# Altium schematic sheet 20-002314-00 Pg3 TP1.SchDoc
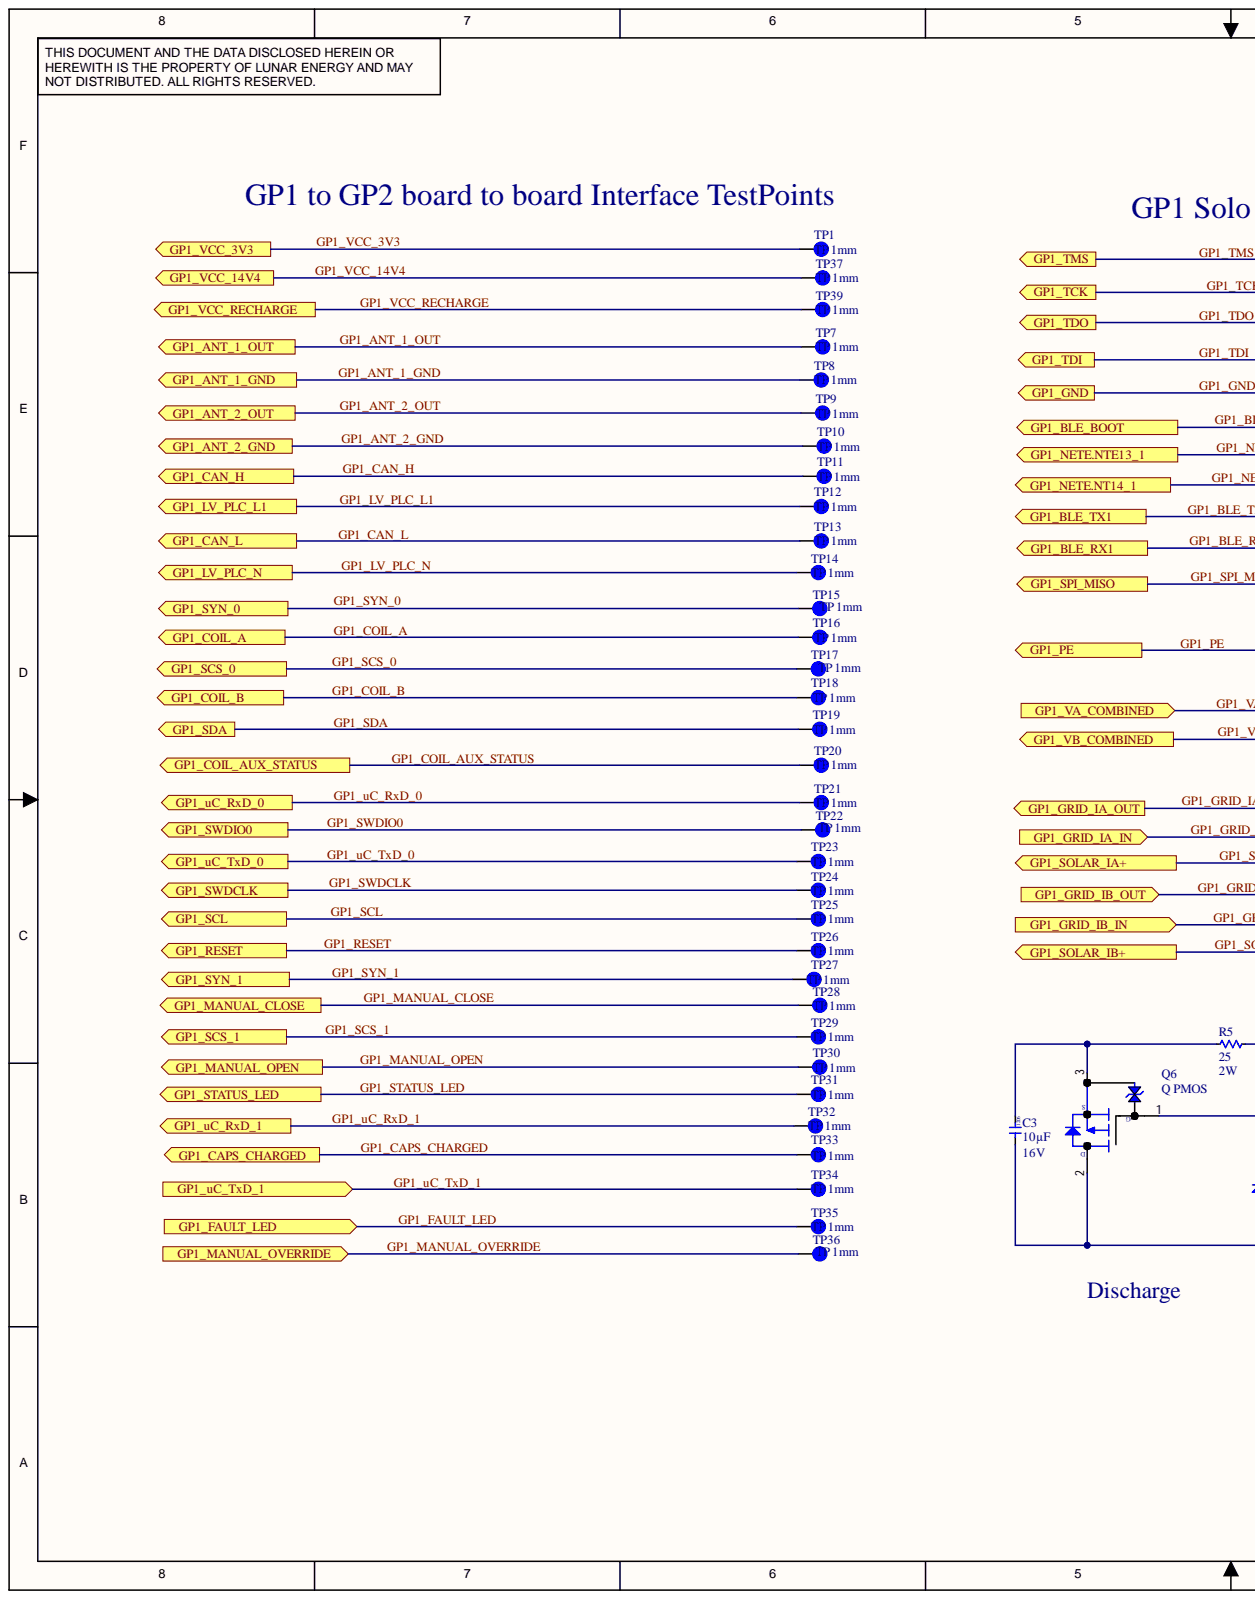
[diagram p0: 20-002314-00 Pg3 TP1.SchDoc, left]
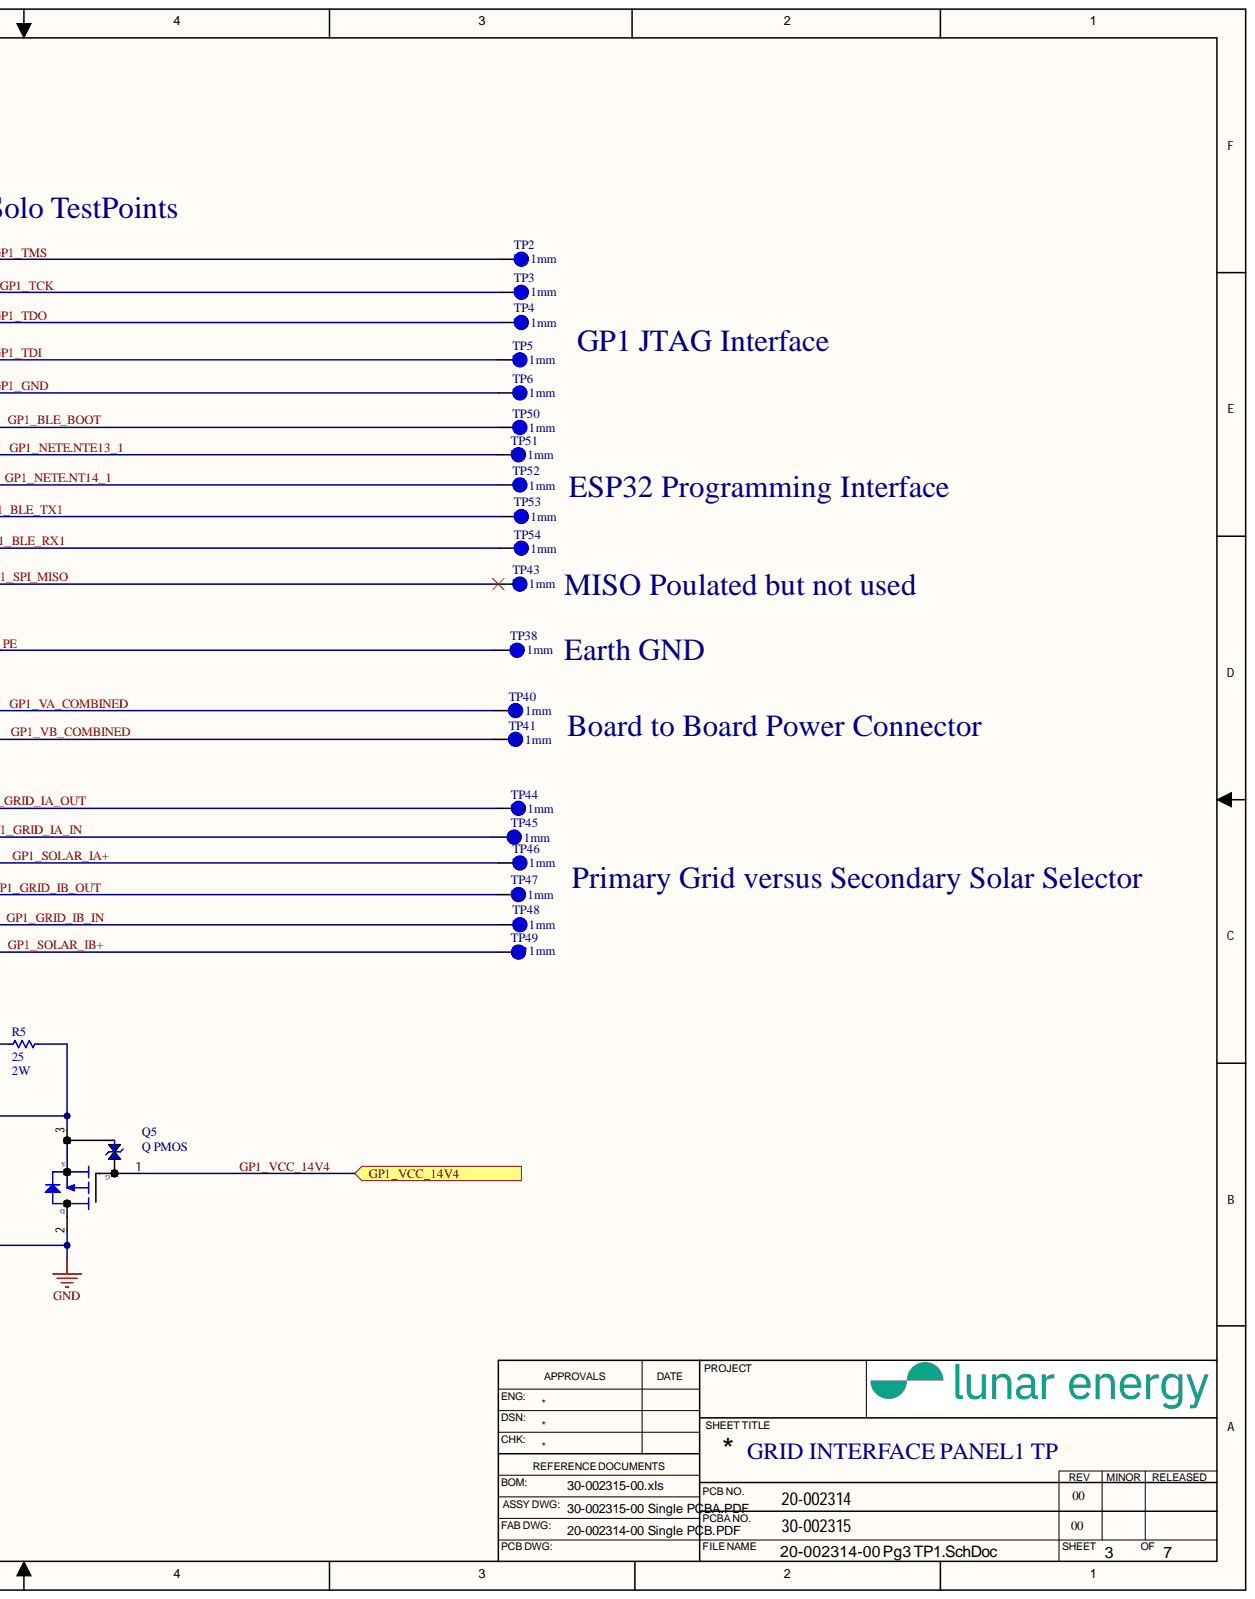
[diagram p1: 20-002314-00 Pg3 TP1.SchDoc, right]

## Parts
C3  value 10µF  DC Voltage Rating: 16V  Size Code: 1206  [CAPC1206 - Lunar]  (hidden comment: CNA5L1X7R1C106K160AE)
Q5  Lunar Sym Name: Q PMOS  [Q PMOS 30V 6A 42mOHM-Footprint-1]  (hidden comment: Q PMOS 30V 6A 42mOHM)
Q6  Lunar Sym Name: Q PMOS  [Q PMOS 30V 6A 42mOHM-Footprint-1]  (hidden comment: Q PMOS 30V 6A 42mOHM)
R5  value 25  Power: 2W  [RESC6435X06N]  (hidden comment: RCP2512W25R0GEB)
TP1  Lunar Sym Name: TP 1mm  [Test Point 1MM Round-Footprint-1]  (hidden comment: Test Point 1mm Round)  (no BOM)
TP2  Lunar Sym Name: TP 1mm  [Test Point 1MM Round-Footprint-1]  (hidden comment: Test Point 1mm Round)  (no BOM)
TP3  Lunar Sym Name: TP 1mm  [Test Point 1MM Round-Footprint-1]  (hidden comment: Test Point 1mm Round)  (no BOM)
TP4  Lunar Sym Name: TP 1mm  [Test Point 1MM Round-Footprint-1]  (hidden comment: Test Point 1mm Round)  (no BOM)
TP5  Lunar Sym Name: TP 1mm  [Test Point 1MM Round-Footprint-1]  (hidden comment: Test Point 1mm Round)  (no BOM)
TP6  Lunar Sym Name: TP 1mm  [Test Point 1MM Round-Footprint-1]  (hidden comment: Test Point 1mm Round)  (no BOM)
TP7  Lunar Sym Name: TP 1mm  [Test Point 1MM Round-Footprint-1]  (hidden comment: Test Point 1mm Round)  (no BOM)
TP8  Lunar Sym Name: TP 1mm  [Test Point 1MM Round-Footprint-1]  (hidden comment: Test Point 1mm Round)  (no BOM)
TP9  Lunar Sym Name: TP 1mm  [Test Point 1MM Round-Footprint-1]  (hidden comment: Test Point 1mm Round)  (no BOM)
TP10  Lunar Sym Name: TP 1mm  [Test Point 1MM Round-Footprint-1]  (hidden comment: Test Point 1mm Round)  (no BOM)
TP11  Lunar Sym Name: TP 1mm  [Test Point 1MM Round-Footprint-1]  (hidden comment: Test Point 1mm Round)  (no BOM)
TP12  Lunar Sym Name: TP 1mm  [Test Point 1MM Round-Footprint-1]  (hidden comment: Test Point 1mm Round)  (no BOM)
TP13  Lunar Sym Name: TP 1mm  [Test Point 1MM Round-Footprint-1]  (hidden comment: Test Point 1mm Round)  (no BOM)
TP14  Lunar Sym Name: TP 1mm  [Test Point 1MM Round-Footprint-1]  (hidden comment: Test Point 1mm Round)  (no BOM)
TP15  Lunar Sym Name: TP 1mm  [Test Point 1MM Round-Footprint-1]  (hidden comment: Test Point 1mm Round)  (no BOM)
TP16  Lunar Sym Name: TP 1mm  [Test Point 1MM Round-Footprint-1]  (hidden comment: Test Point 1mm Round)  (no BOM)
TP17  Lunar Sym Name: TP 1mm  [Test Point 1MM Round-Footprint-1]  (hidden comment: Test Point 1mm Round)  (no BOM)
TP18  Lunar Sym Name: TP 1mm  [Test Point 1MM Round-Footprint-1]  (hidden comment: Test Point 1mm Round)  (no BOM)
TP19  Lunar Sym Name: TP 1mm  [Test Point 1MM Round-Footprint-1]  (hidden comment: Test Point 1mm Round)  (no BOM)
TP20  Lunar Sym Name: TP 1mm  [Test Point 1MM Round-Footprint-1]  (hidden comment: Test Point 1mm Round)  (no BOM)
TP21  Lunar Sym Name: TP 1mm  [Test Point 1MM Round-Footprint-1]  (hidden comment: Test Point 1mm Round)  (no BOM)
TP22  Lunar Sym Name: TP 1mm  [Test Point 1MM Round-Footprint-1]  (hidden comment: Test Point 1mm Round)  (no BOM)
TP23  Lunar Sym Name: TP 1mm  [Test Point 1MM Round-Footprint-1]  (hidden comment: Test Point 1mm Round)  (no BOM)
TP24  Lunar Sym Name: TP 1mm  [Test Point 1MM Round-Footprint-1]  (hidden comment: Test Point 1mm Round)  (no BOM)
TP25  Lunar Sym Name: TP 1mm  [Test Point 1MM Round-Footprint-1]  (hidden comment: Test Point 1mm Round)  (no BOM)
TP26  Lunar Sym Name: TP 1mm  [Test Point 1MM Round-Footprint-1]  (hidden comment: Test Point 1mm Round)  (no BOM)
TP27  Lunar Sym Name: TP 1mm  [Test Point 1MM Round-Footprint-1]  (hidden comment: Test Point 1mm Round)  (no BOM)
TP28  Lunar Sym Name: TP 1mm  [Test Point 1MM Round-Footprint-1]  (hidden comment: Test Point 1mm Round)  (no BOM)
TP29  Lunar Sym Name: TP 1mm  [Test Point 1MM Round-Footprint-1]  (hidden comment: Test Point 1mm Round)  (no BOM)
TP30  Lunar Sym Name: TP 1mm  [Test Point 1MM Round-Footprint-1]  (hidden comment: Test Point 1mm Round)  (no BOM)
TP31  Lunar Sym Name: TP 1mm  [Test Point 1MM Round-Footprint-1]  (hidden comment: Test Point 1mm Round)  (no BOM)
TP32  Lunar Sym Name: TP 1mm  [Test Point 1MM Round-Footprint-1]  (hidden comment: Test Point 1mm Round)  (no BOM)
TP33  Lunar Sym Name: TP 1mm  [Test Point 1MM Round-Footprint-1]  (hidden comment: Test Point 1mm Round)  (no BOM)
TP34  Lunar Sym Name: TP 1mm  [Test Point 1MM Round-Footprint-1]  (hidden comment: Test Point 1mm Round)  (no BOM)
TP35  Lunar Sym Name: TP 1mm  [Test Point 1MM Round-Footprint-1]  (hidden comment: Test Point 1mm Round)  (no BOM)
TP36  Lunar Sym Name: TP 1mm  [Test Point 1MM Round-Footprint-1]  (hidden comment: Test Point 1mm Round)  (no BOM)
TP37  Lunar Sym Name: TP 1mm  [Test Point 1MM Round-Footprint-1]  (hidden comment: Test Point 1mm Round)  (no BOM)
TP38  Lunar Sym Name: TP 1mm  [Test Point 1MM Round-Footprint-1]  (hidden comment: Test Point 1mm Round)  (no BOM)
TP39  Lunar Sym Name: TP 1mm  [Test Point 1MM Round-Footprint-1]  (hidden comment: Test Point 1mm Round)  (no BOM)
TP40  Lunar Sym Name: TP 1mm  [Test Point 1MM Round-Footprint-1]  (hidden comment: Test Point 1mm Round)  (no BOM)
TP41  Lunar Sym Name: TP 1mm  [Test Point 1MM Round-Footprint-1]  (hidden comment: Test Point 1mm Round)  (no BOM)
TP43  Lunar Sym Name: TP 1mm  [Test Point 1MM Round-Footprint-1]  (hidden comment: Test Point 1mm Round)  (no BOM)
TP44  Lunar Sym Name: TP 1mm  [Test Point 1MM Round-Footprint-1]  (hidden comment: Test Point 1mm Round)  (no BOM)
TP45  Lunar Sym Name: TP 1mm  [Test Point 1MM Round-Footprint-1]  (hidden comment: Test Point 1mm Round)  (no BOM)
TP46  Lunar Sym Name: TP 1mm  [Test Point 1MM Round-Footprint-1]  (hidden comment: Test Point 1mm Round)  (no BOM)
TP47  Lunar Sym Name: TP 1mm  [Test Point 1MM Round-Footprint-1]  (hidden comment: Test Point 1mm Round)  (no BOM)
TP48  Lunar Sym Name: TP 1mm  [Test Point 1MM Round-Footprint-1]  (hidden comment: Test Point 1mm Round)  (no BOM)
TP49  Lunar Sym Name: TP 1mm  [Test Point 1MM Round-Footprint-1]  (hidden comment: Test Point 1mm Round)  (no BOM)
TP50  Lunar Sym Name: TP 1mm  [Test Point 1MM Round-Footprint-1]  (hidden comment: Test Point 1mm Round)  (no BOM)
TP51  Lunar Sym Name: TP 1mm  [Test Point 1MM Round-Footprint-1]  (hidden comment: Test Point 1mm Round)  (no BOM)
TP52  Lunar Sym Name: TP 1mm  [Test Point 1MM Round-Footprint-1]  (hidden comment: Test Point 1mm Round)  (no BOM)
TP53  Lunar Sym Name: TP 1mm  [Test Point 1MM Round-Footprint-1]  (hidden comment: Test Point 1mm Round)  (no BOM)
TP54  Lunar Sym Name: TP 1mm  [Test Point 1MM Round-Footprint-1]  (hidden comment: Test Point 1mm Round)  (no BOM)

## Ports
GP1_ANT_1_GND, GP1_ANT_1_OUT, GP1_ANT_2_GND, GP1_ANT_2_OUT, GP1_BLE_BOOT, GP1_BLE_RX1, GP1_BLE_TX1, GP1_CAN_H, GP1_CAN_L, GP1_CAPS_CHARGED, GP1_COIL_A, GP1_COIL_AUX_STATUS, GP1_COIL_B, GP1_FAULT_LED, GP1_GND, GP1_GRID_IA_IN, GP1_GRID_IA_OUT, GP1_GRID_IB_IN, GP1_GRID_IB_OUT, GP1_LV_PLC_L1, GP1_LV_PLC_N, GP1_MANUAL_CLOSE, GP1_MANUAL_OPEN, GP1_MANUAL_OVERRIDE, GP1_NETE.NT14_1, GP1_NETE.NTE13_1, GP1_PE, GP1_RESET, GP1_SCL, GP1_SCS_0, GP1_SCS_1, GP1_SDA, GP1_SOLAR_IA+, GP1_SOLAR_IB+, GP1_SPI_MISO, GP1_STATUS_LED, GP1_SWDCLK, GP1_SWDIO0, GP1_SYN_0, GP1_SYN_1, GP1_TCK, GP1_TDI, GP1_TDO, GP1_TMS, GP1_uC_RxD_0, GP1_uC_RxD_1, GP1_uC_TxD_0, GP1_uC_TxD_1, GP1_VA_COMBINED, GP1_VB_COMBINED, GP1_VCC_3V3, GP1_VCC_14V4, GP1_VCC_RECHARGE

## Nets
GND: C3.2 Q5.2 Q6.2
GP1_ANT_1_GND: TP8.1 ; port GP1_ANT_1_GND
GP1_ANT_1_OUT: TP7.1 ; port GP1_ANT_1_OUT
GP1_ANT_2_GND: TP10.1 ; port GP1_ANT_2_GND
GP1_ANT_2_OUT: TP9.1 ; port GP1_ANT_2_OUT
GP1_BLE_BOOT: TP50.1 ; port GP1_BLE_BOOT
GP1_BLE_RX1: TP54.1 ; port GP1_BLE_RX1
GP1_BLE_TX1: TP53.1 ; port GP1_BLE_TX1
GP1_CAN_H: TP11.1 ; port GP1_CAN_H
GP1_CAN_L: TP13.1 ; port GP1_CAN_L
GP1_CAPS_CHARGED: TP33.1 ; port GP1_CAPS_CHARGED
GP1_COIL_A: TP16.1 ; port GP1_COIL_A
GP1_COIL_AUX_STATUS: TP20.1 ; port GP1_COIL_AUX_STATUS
GP1_COIL_B: TP18.1 ; port GP1_COIL_B
GP1_FAULT_LED: TP35.1 ; port GP1_FAULT_LED
GP1_GND: TP6.1 ; port GP1_GND
GP1_GRID_IA_IN: TP45.1 ; port GP1_GRID_IA_IN
GP1_GRID_IA_OUT: TP44.1 ; port GP1_GRID_IA_OUT
GP1_GRID_IB_IN: TP48.1 ; port GP1_GRID_IB_IN
GP1_GRID_IB_OUT: TP47.1 ; port GP1_GRID_IB_OUT
GP1_LV_PLC_L1: TP12.1 ; port GP1_LV_PLC_L1
GP1_LV_PLC_N: TP14.1 ; port GP1_LV_PLC_N
GP1_MANUAL_CLOSE: TP28.1 ; port GP1_MANUAL_CLOSE
GP1_MANUAL_OPEN: TP30.1 ; port GP1_MANUAL_OPEN
GP1_MANUAL_OVERRIDE: TP36.1 ; port GP1_MANUAL_OVERRIDE
GP1_NETE.NT14_1: TP52.1 ; port GP1_NETE.NT14_1
GP1_NETE.NTE13_1: TP51.1 ; port GP1_NETE.NTE13_1
GP1_PE: TP38.1 ; port GP1_PE
GP1_RESET: TP26.1 ; port GP1_RESET
GP1_SCL: TP25.1 ; port GP1_SCL
GP1_SCS_0: TP17.1 ; port GP1_SCS_0
GP1_SCS_1: TP29.1 ; port GP1_SCS_1
GP1_SDA: TP19.1 ; port GP1_SDA
GP1_SOLAR_IA+: TP46.1 ; port GP1_SOLAR_IA+
GP1_SOLAR_IB+: TP49.1 ; port GP1_SOLAR_IB+
GP1_SPI_MISO: TP43.1 ; port GP1_SPI_MISO
GP1_STATUS_LED: TP31.1 ; port GP1_STATUS_LED
GP1_SWDCLK: TP24.1 ; port GP1_SWDCLK
GP1_SWDIO0: TP22.1 ; port GP1_SWDIO0
GP1_SYN_0: TP15.1 ; port GP1_SYN_0
GP1_SYN_1: TP27.1 ; port GP1_SYN_1
GP1_TCK: TP3.1 ; port GP1_TCK
GP1_TDI: TP5.1 ; port GP1_TDI
GP1_TDO: TP4.1 ; port GP1_TDO
GP1_TMS: TP2.1 ; port GP1_TMS
GP1_uC_RxD_0: TP21.1 ; port GP1_uC_RxD_0
GP1_uC_RxD_1: TP32.1 ; port GP1_uC_RxD_1
GP1_uC_TxD_0: TP23.1 ; port GP1_uC_TxD_0
GP1_uC_TxD_1: TP34.1 ; port GP1_uC_TxD_1
GP1_VA_COMBINED: TP40.1 ; port GP1_VA_COMBINED
GP1_VB_COMBINED: TP41.1 ; port GP1_VB_COMBINED
GP1_VCC_3V3: TP1.1 ; port GP1_VCC_3V3
GP1_VCC_14V4: Q5.1 TP37.1 ; port GP1_VCC_14V4
GP1_VCC_RECHARGE: TP39.1 ; port GP1_VCC_RECHARGE
NetC3_1: C3.1 Q6.3 R5.1
NetQ5_3: Q5.3 Q6.1 R5.2

## Library data of the parts
C3 = CMP-001-00139-3: Ceramic Capacitor, Multilayer, Ceramic, 16V, 10% +Tol, 10% -Tol, X7R, 15% TC, 10uF, Surface Mount, 1206; Manufacturer: TDK; Lunar PN: 10-001734; Multilayer: Yes; Number of Terminals/Pins: 2; Reference Standard: AEC-Q200; Capacitance: 10uF; Capacitor Type: Ceramic; Component Kind: Standard; Dielectric Material: Ceramic; JESD-609 Code: e3; Manufacturer PN: CNA5L1X7R1C106K160AE; Max Operating Temperature: 125°C; Min Operating Temperature: -55°C; Mount: Surface Mount; Negative Tolerance: 10%; Package Shape: Rectangular; Packaging: Tape and Reel; Positive Tolerance: 10%; Production Intent: YES; Rated DC Voltage (URdc): 16V; REACH SVHC: Yes; RoHS Compliant: Yes; Temperature Characteristics Code: X7R; Terminal Finish: Nickel; Termination: Wraparound; Tolerance: 10%; Library Name: Lunar Energy
Q5 Q6 = CMP-013-00032-2: SSM3J332R,LF Small Signal Field-Effect Transistor, 6A I(D), 30V, 1-Element, P-Channel, Silicon, Metal-oxide Semiconductor FET; Manufacturer: Toshiba Semiconductor and Storage; Drain-source On Resistance-Max: 42mR; Lunar PN: 10-000990; Number of Elements: 1; Number of Terminals/Pins: 3; Operating Mode: ENHANCEMENT MODE; Package Body Material: Plastic; Production Intent: YES; Transistor Application: SWITCHING; Transistor Type: MOSFET (Metal Oxide); Vgs (Max): ±12V; Vgs(th) (Max) @ Id: 1.2V @ 1mA; Component Kind: Standard; Drain Current-Max (ID): 6A; DS Breakdown Voltage-Min: 30V; FET Technology: METAL-OXIDE SEMICONDUCTOR; JESD-30 Code: R-PDSO-F3; Manufacturer PN: SSM3J332R,LF; Package Shape: Rectangular; Package Style: SMALL OUTLINEMeter; Pins: 3; Polarity/Channel Type: P-CHANNEL; REACH SVHC: No SVHC; RoHS Compliant: Yes; Surface Mount: Yes; Terminal Form: Flat; Terminal Position: DUAL; Transistor Element Material: SILICON; Library Name: Lunar Energy
R5 = CMP-009-00224-3: Fixed Resistor, Metal Glaze/thick Film, 2W, 220ohm, 250V, 1% +/-Tol, 100ppm/Cel, Surface Mount, 2512; Manufacturer: TE Connectivity; AEC-Q: Yes; Lunar PN: 10-002759; Lunar Sym Name: RES SMD 25 OHM 2% 3.5W 2512; Case/Package: 2512; Component Kind: Standard; Manufacturer PN: RCP2512W25R0GEB; Max Operating Temperature: 155°C; Min Operating Temperature: -55°C; Mounting Technology: SMT; Pins: 2; Production Intent: YES; RoHS Compliant: Yes; Tolerance: 1%; Library Name: Lunar Energy
TP1-TP41 TP43-TP54 = CMP-00030-00004-12: Test Point 1mm Round, No solder paste; Manufacturer: Lunar Energy; Manufacturer PN: Lunar-TP-1mm; Production Intent: YES; Library Name: Lunar Energy; Mounting Technology: SMD
